# Altium schematic sheet Schematic Prints("All Documents",Physical)
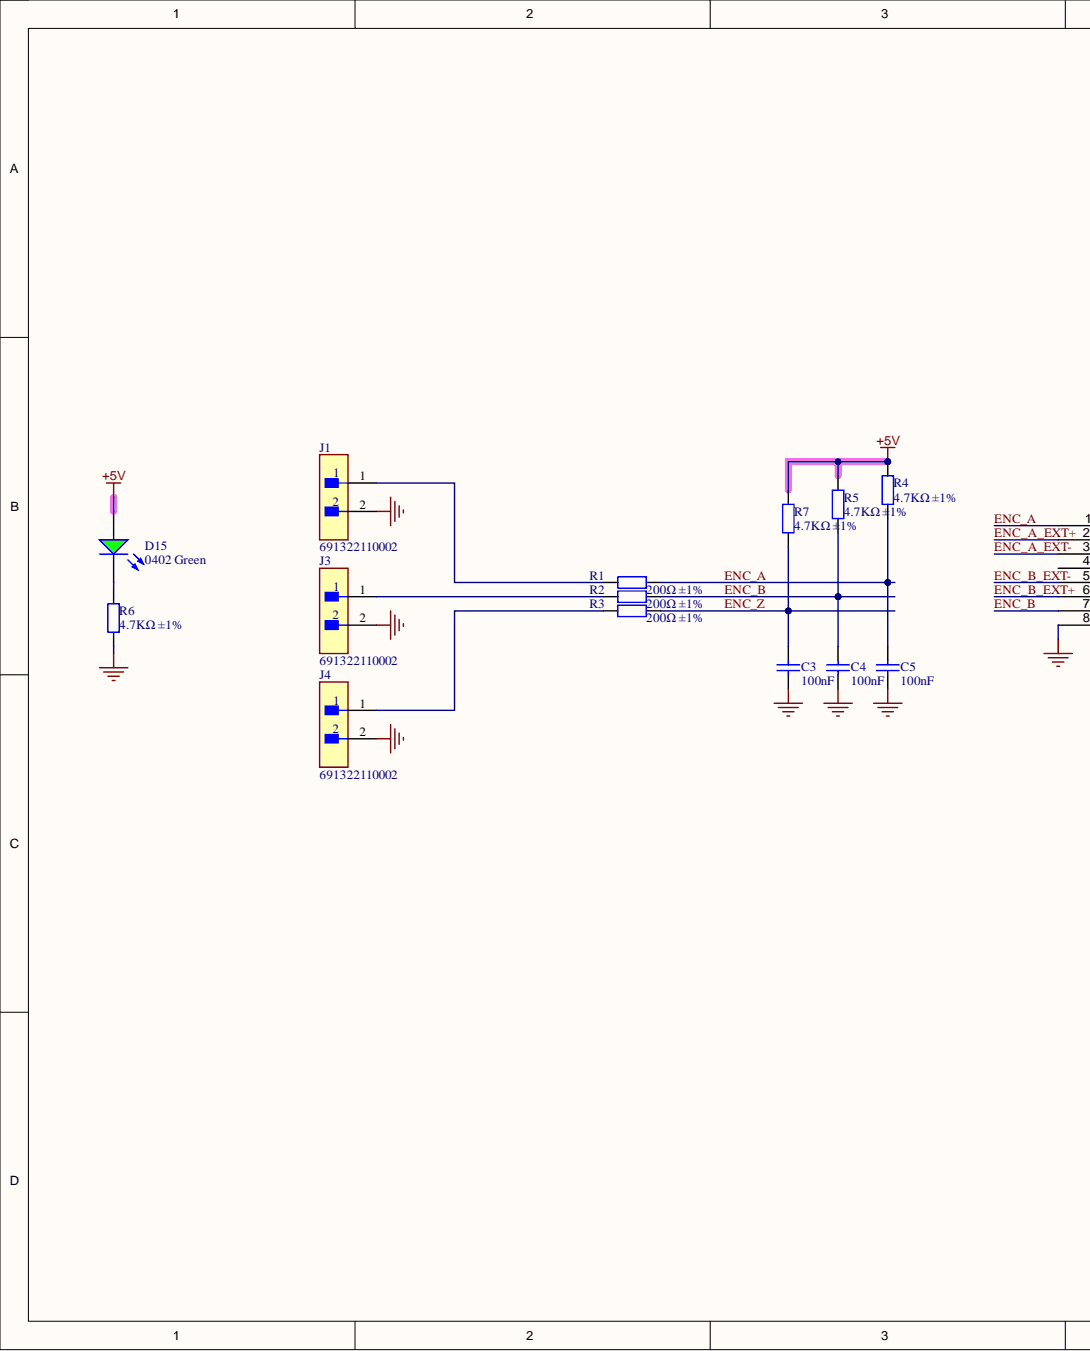
[diagram p0: Schematic Prints("All Documents",Physical), left]
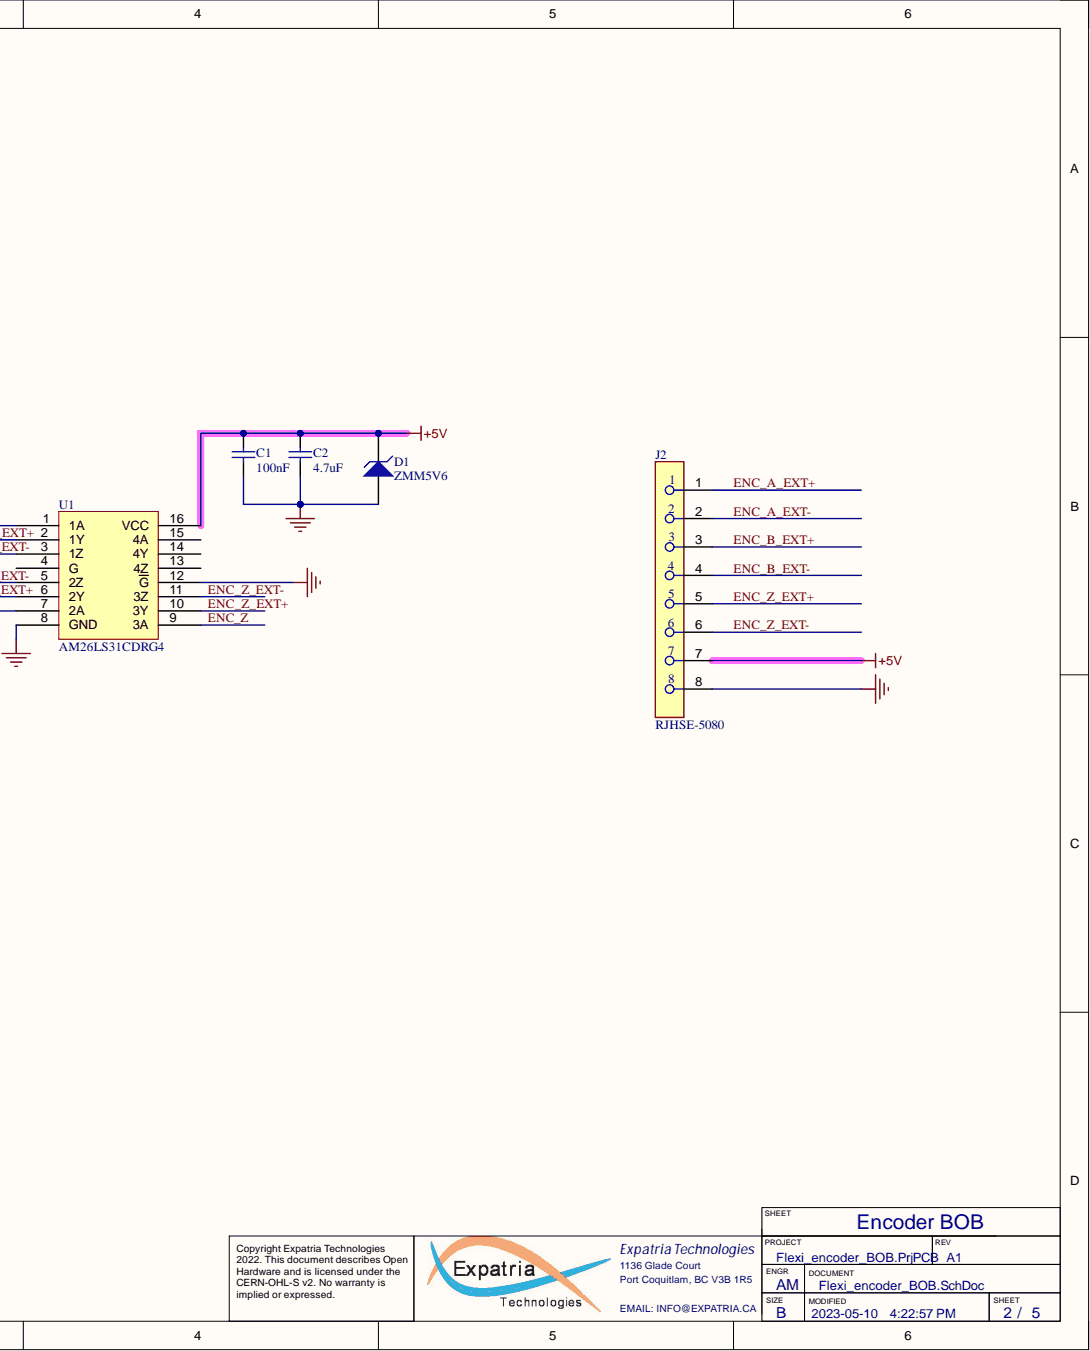
[diagram p1: Schematic Prints("All Documents",Physical), right]

## Parts
C1  100nF  [0402_C]
C2  4.7uF  [0402_C]
C3  100nF  [0402_C]
C4  100nF  [0402_C]
C5  100nF  [0402_C]
D1  ZMM5V6  [LL-34]
D15  0402 Green  Color: Green  Package: 0402  [LED 0402_1005 RED]
J1  691322110002  [FP-691322110002-MFG]
J2  RJHSE-5080  [FP-RJHSE-5080-MFG]
J3  691322110002  [FP-691322110002-MFG]
J4  691322110002  [FP-691322110002-MFG]
R1  200Ω ±1%  Resistance: 200Ω  [0402_R]
R2  200Ω ±1%  Resistance: 200Ω  [0402_R]
R3  200Ω ±1%  Resistance: 200Ω  [0402_R]
R4  4.7KΩ ±1%  Resistance: 4.7KΩ  [0402_R]
R5  4.7KΩ ±1%  Resistance: 4.7KΩ  [0402_R]
R6  4.7KΩ ±1%  Resistance: 4.7KΩ  [0402_R]
R7  4.7KΩ ±1%  Resistance: 4.7KΩ  [0402_R]
U1  AM26LS31CDRG4  [SOIC-16_150mil]

## Nets
+5V: C1.1 C2.1 D1.2 D15.A J2.7 R4.2 R5.2 R7.2 U1.16(VCC)
GND: C1.2 C2.2 C3.2 C4.2 C5.2 D1.1 J1.2 J2.8 J3.2 J4.2 R6.1 U1.8(GND) U1.12(G)
ENC_A: C5.1 R1.1 R4.1 U1.1(1A)
ENC_A_EXT+: J2.1 U1.2(1Y)
ENC_A_EXT-: J2.2 U1.3(1Z)
ENC_B: C4.1 R2.1 R5.1 U1.7(2A)
ENC_B_EXT+: J2.3 U1.6(2Y)
ENC_B_EXT-: J2.4 U1.5(2Z)
ENC_Z: C3.1 R3.1 R7.1 U1.9(3A)
ENC_Z_EXT+: J2.5 U1.10(3Y)
ENC_Z_EXT-: J2.6 U1.11(3Z)
NetD15_K: D15.K R6.2
NetJ1_1: J1.1 R1.2
NetJ3_1: J3.1 R2.2
NetJ4_1: J4.1 R3.2
NetU1_4: U1.4(G)
NetU1_13: U1.13(4Z)
NetU1_14: U1.14(4Y)
NetU1_15: U1.15(4A)

## Library data of the parts
C1 C3-C5 = 0402 100nF (104) 10% 16V: Chip capacitors; Manufacturer: Samsung Electro-Mechanics; PartNumber: CL05B104KO5NNNC; Tolerance: 10%; Voltage: 16V; Capacitance: 100nF; Component Kind: Standard; ComponentLink1Description: Supplier link; ComponentLink1URL: http://www.szlcsc.com/product/details_1877.html; Library Name: Cap.SchLib; Package: 0402; Supplier: LC; SuppliersPartNumber: C1525
C2 = 0402 4.7uF (475) 20% 10V: Chip capacitor; Manufacturer: Samsung Electro-Mechanics; PartNumber: CL05A475MP5NRNC; Tolerance: 20%; Voltage: 10V; Capacitance: 4.7uF; Component Kind: Standard; ComponentLink1Description: Supplier link; ComponentLink1URL: http://www.szlcsc.com/product/details_24469.html; Library Name: Cap.SchLib; Package: 0402; Supplier: LC; SuppliersPartNumber: C23733
D1 = LL-34 ZMM5V6: 500mW - 0.1uA @ 1V 5.6V LL-34 Zener Diodes ROHS; Component Kind: Standard; ComponentLink1Description: 供应商链接; ComponentLink1URL: http://www.szlcsc.com/product/details_8541.html; Library Name: Zener.SchLib; Package: LL-34; Supplier: LC; SuppliersPartNumber: C8062
D15 = 0402 LED Green: LED; Component Kind: Standard; Library Name: LED.SchLib; Supplier: LC; SuppliersPartNumber: C130723
J1 J3 J4 = CMP-1502-01791-2: TERM BLOCK HDR 2POS 90DEG 3.5MM; Current: 10A; Number of Contacts: 2; Number of Levels: 1; Voltage: 300V; Color: Green; Component Kind: Standard; Contact Material: Brass; Flammability Rating: UL94 V-0; Height: 9.2mm; Housing Material: Nylon; Lead Free: Lead Free; Length: 10.5mm; Library Name: Altium Content Vault; Mount: Through Hole; Number of Positions: 2; Number of Positions Per Level: 2; Number of Rows: 1; Package Quantity: 1000; Packaging: Bulk; Pitch: 3.5mm; RoHS Compliant: Yes; Series: WR-TBL Series 3221; Termination: Solder; Width: 8.1mm
J2 = CMP-02366-000003-1: CONN MOD JACK 8P8C R/A UNSHLD; Manufacturer: Pulse Electronics Network; Manufacturer Part Number: E5J88-00LJG2-L; Pitch: 1.02mm; Component Kind: Standard; ComponentLink2Description: Datasheet; ComponentLink2URL: https://cdn.amphenol-icc.com/media/wysiwyg/files/documentation/datasheet/inputoutput/io-modjack-rjhse.pdf; Device Class L1: Connectors; Digikey Description: CONN MOD JACK 8P8C R/A UNSHLD; Library Name: Altium Content Vault; Package: CONN_MOD_JACK; SuppliersPartNumber: C385834
R1-R3 = 0402 200R (2000) 1%: Chip resistor; Manufacturer: Uniroyal Elec; PartNumber: 0402WGF2000TCE; Power: 1/16W; Component Kind: Standard; ComponentLink1Description: Supplier link; ComponentLink1URL: http://www.szlcsc.com/product/details_25830.html; Library Name: RES.SchLib; Package: 0402; Supplier: LC; SuppliersPartNumber: C25087; Tolerance: 1%
R4-R7 = 0402 4.7K (4701) 1%: Chip resistor; Manufacturer: Uniroyal Elec; PartNumber: 0402WGF4701TCE; Power: 1/16W; Component Kind: Standard; ComponentLink1Description: Supplier link; ComponentLink1URL: http://www.szlcsc.com/product/details_26643.html; Library Name: RES.SchLib; Package: 0402; Supplier: LC; SuppliersPartNumber: C25900; Tolerance: 1%
U1 = AM26LS31CDRG4_[C6179]: 4/0 Driver - RS422, RS485 16-SOIC; Manufacturer 1: Texas Instruments; Component Kind: Standard; ComponentLink1Description: 供应商链接; ComponentLink1URL: http://www.szlcsc.com/product/details_6645.html; Library Name: IC.SchLib; Manufacturer Part Number 1: AM26LS31CDR; Package: SOIC-16_150mil; Supplier: LC; SuppliersPartNumber: C6179
